# Altium schematic sheet camera_k60.SchDoc
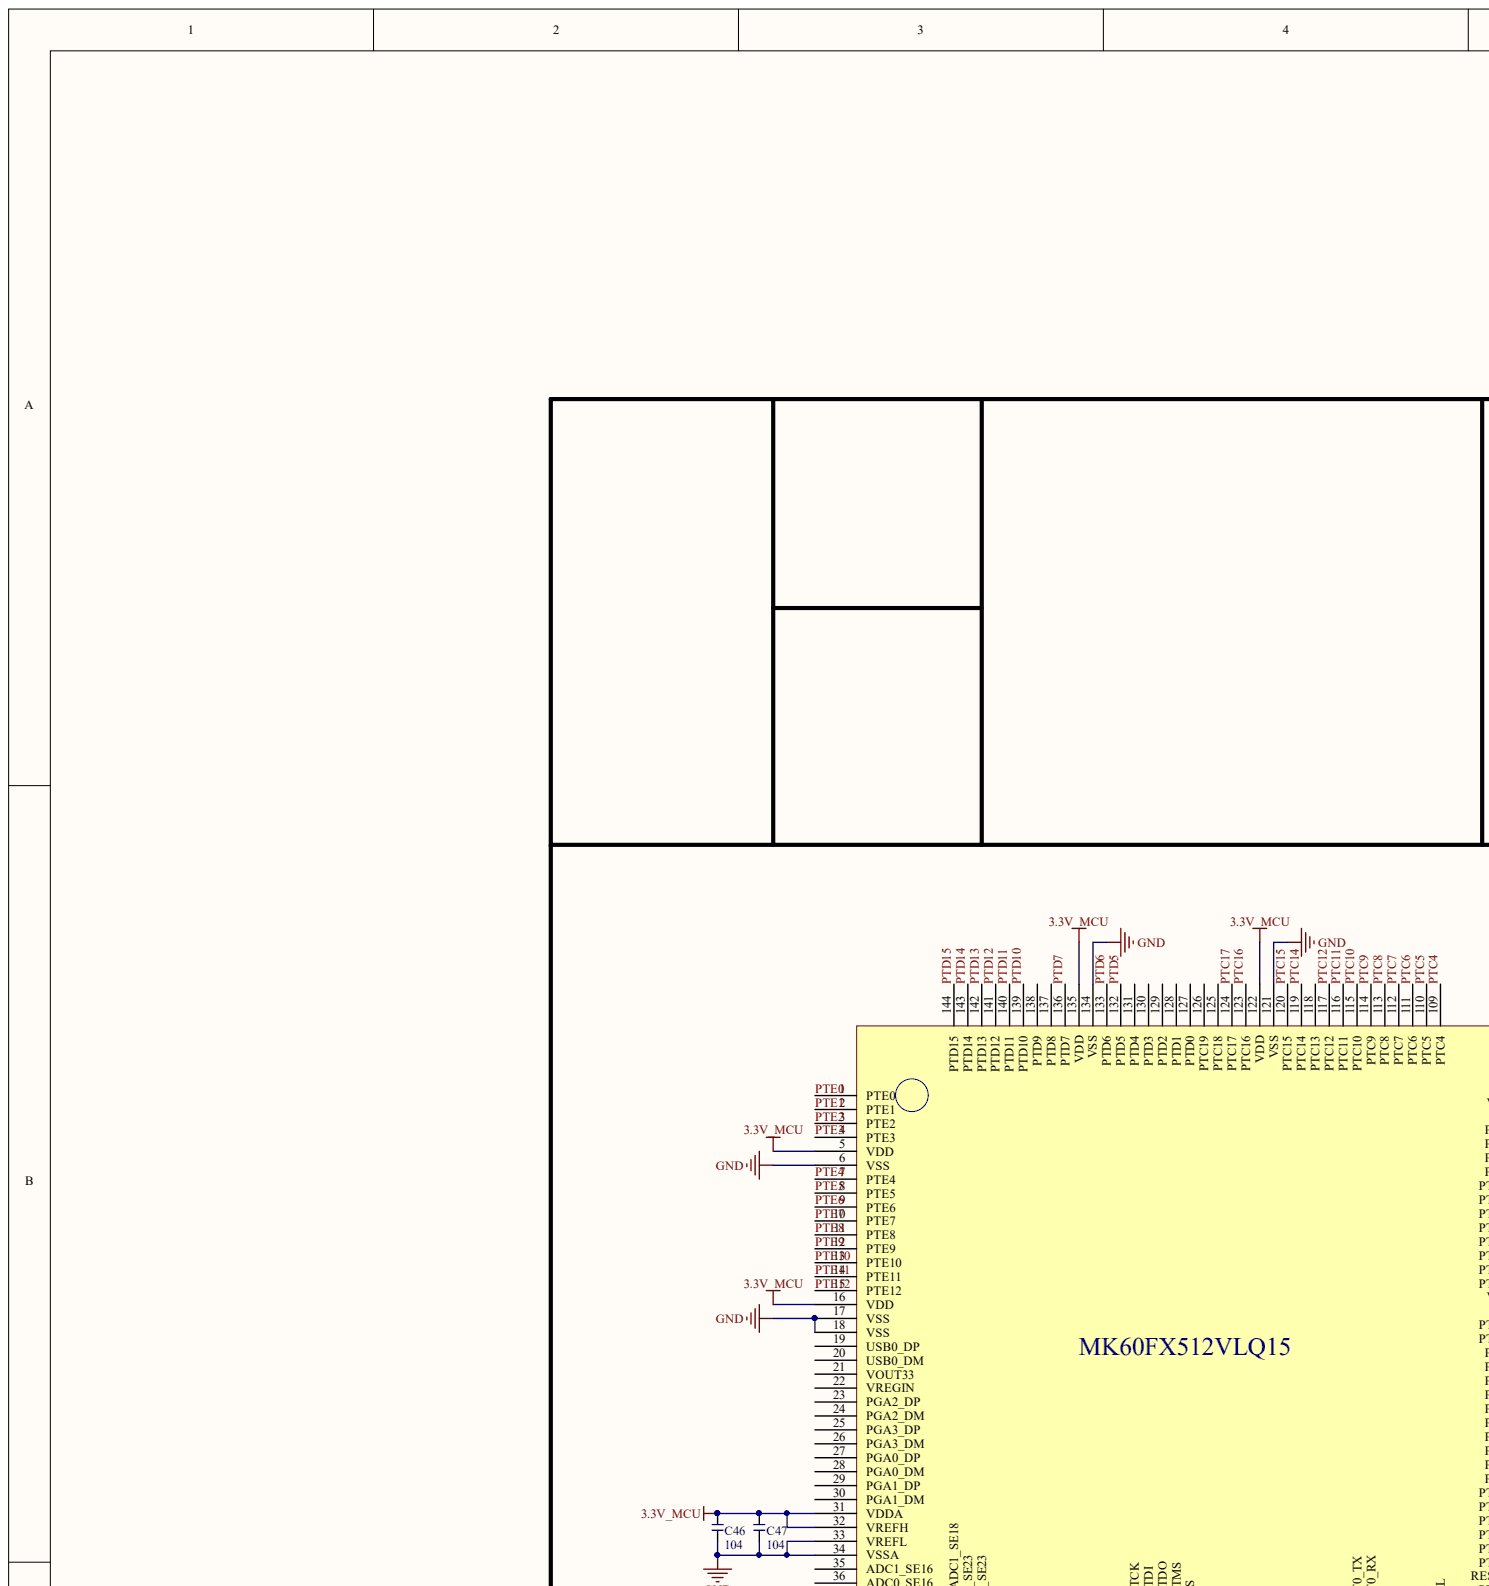
[diagram p0: camera_k60.SchDoc, top left]
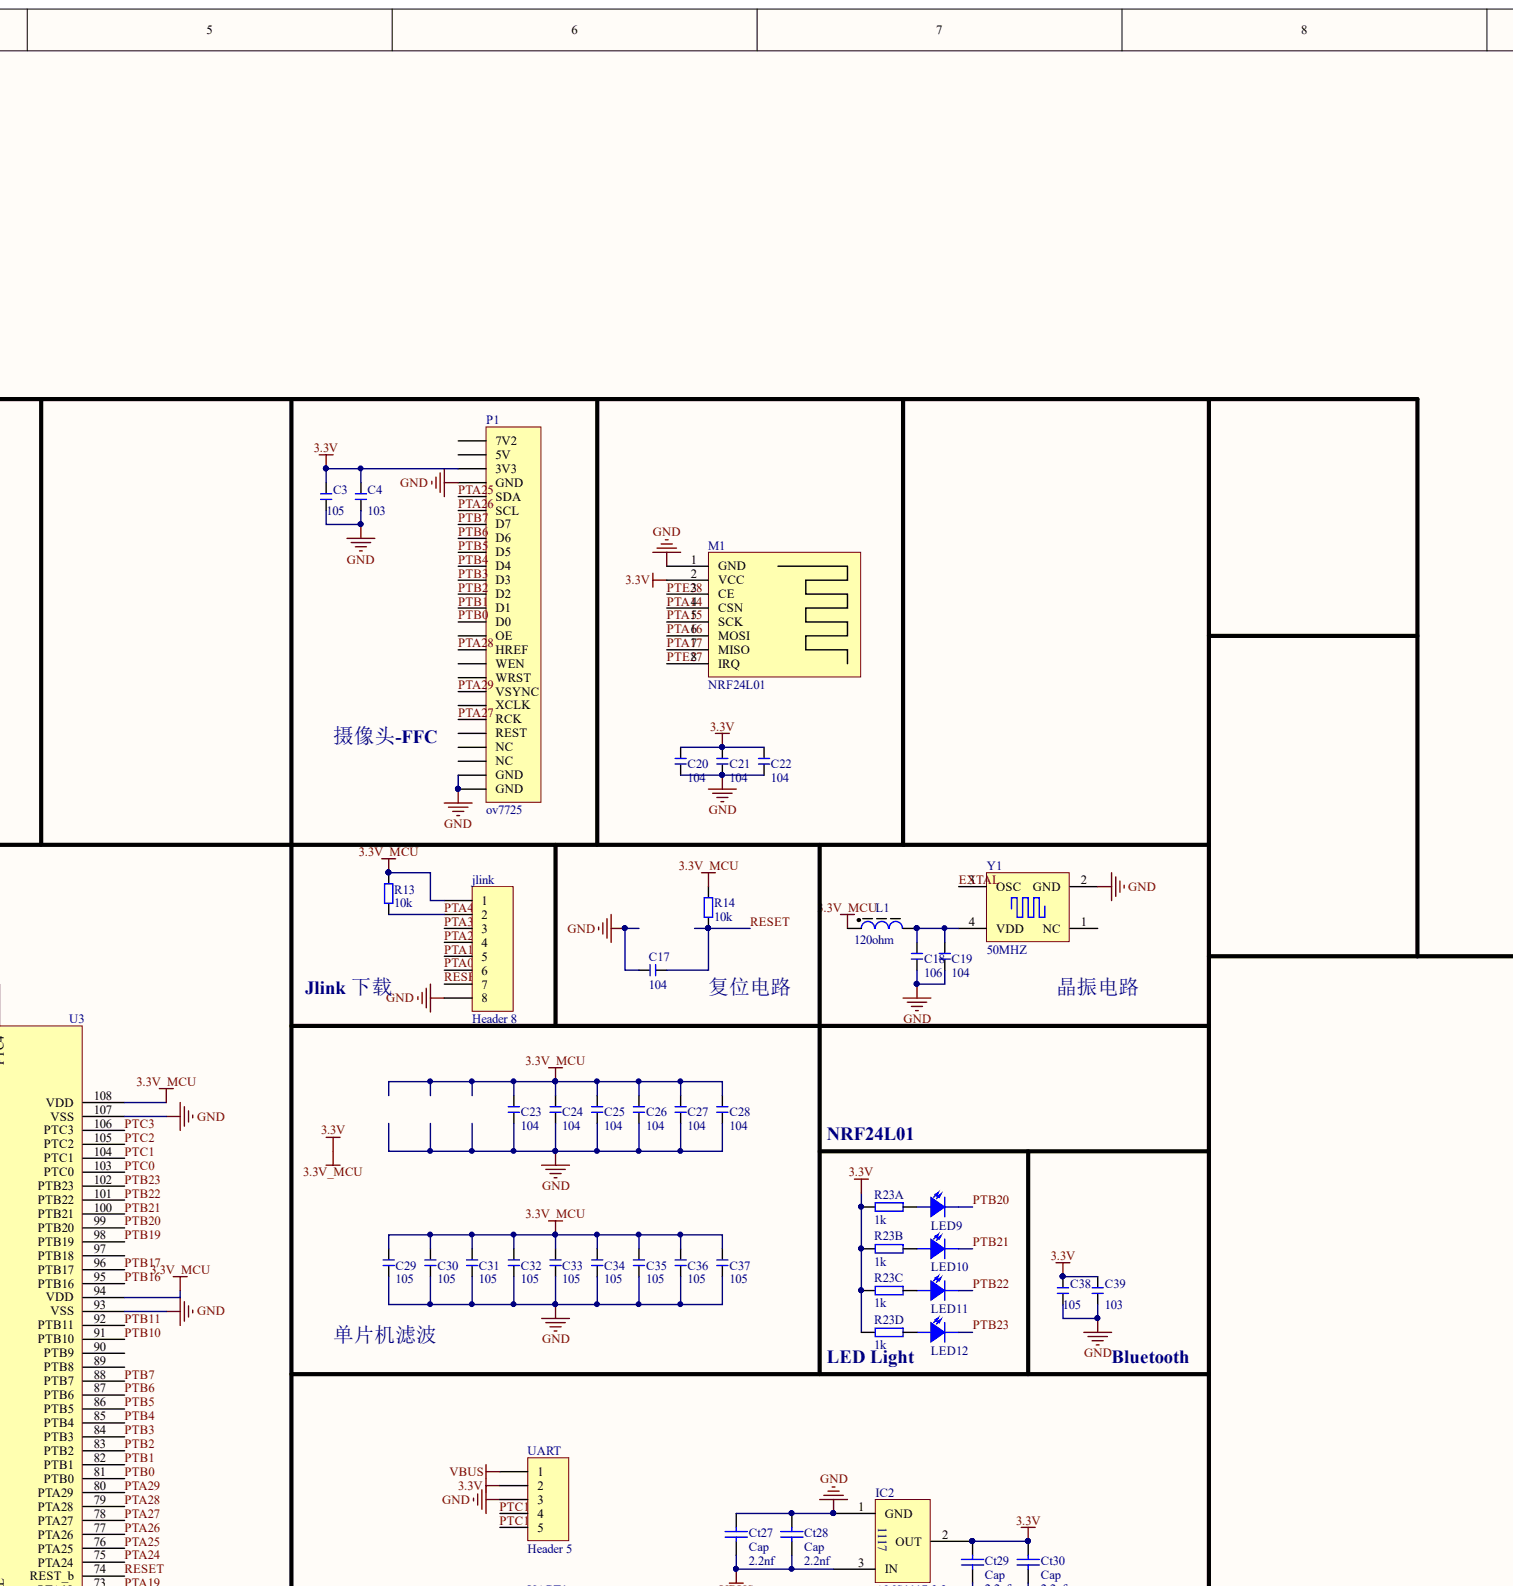
[diagram p1: camera_k60.SchDoc, top centre]
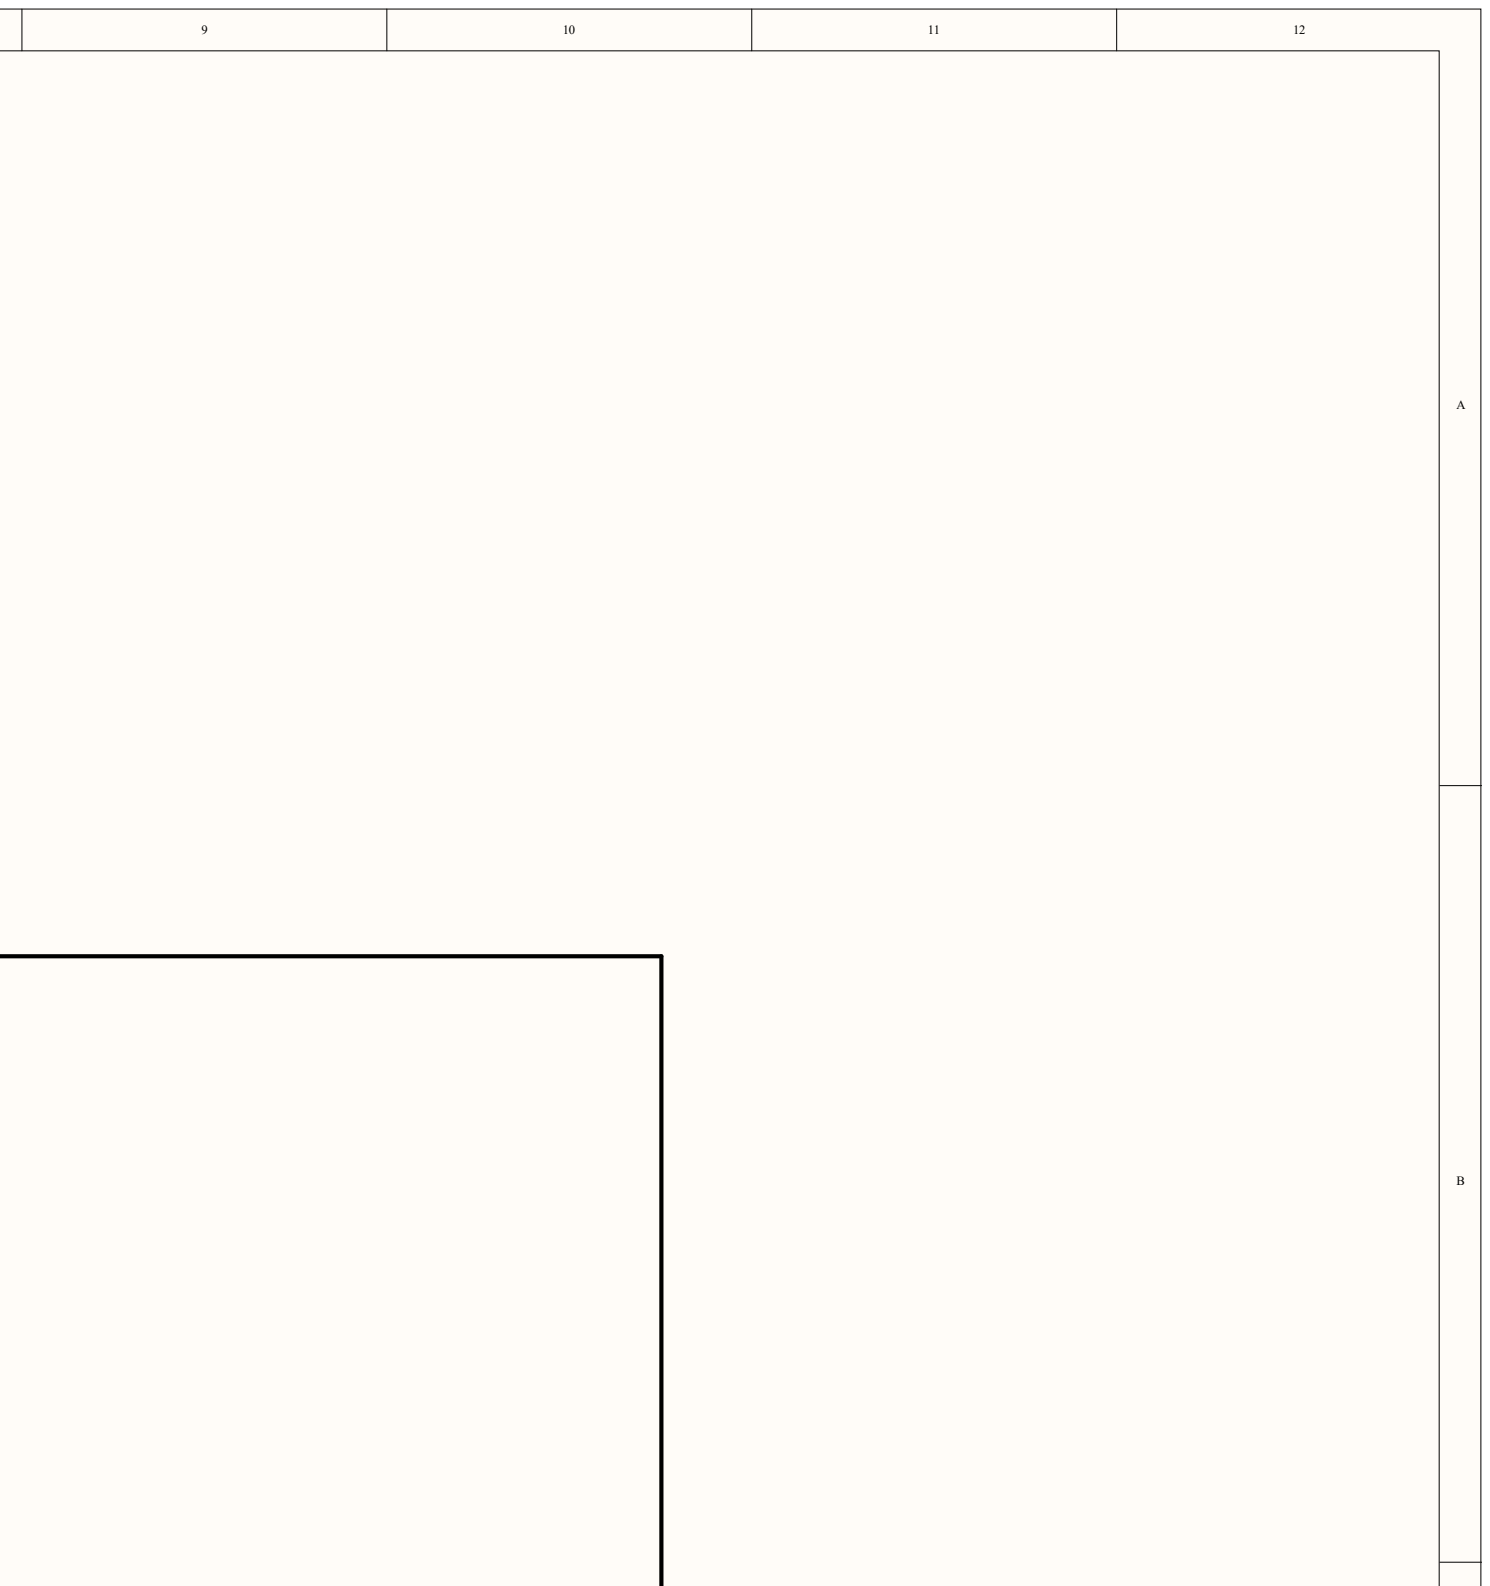
[diagram p2: camera_k60.SchDoc, top right]
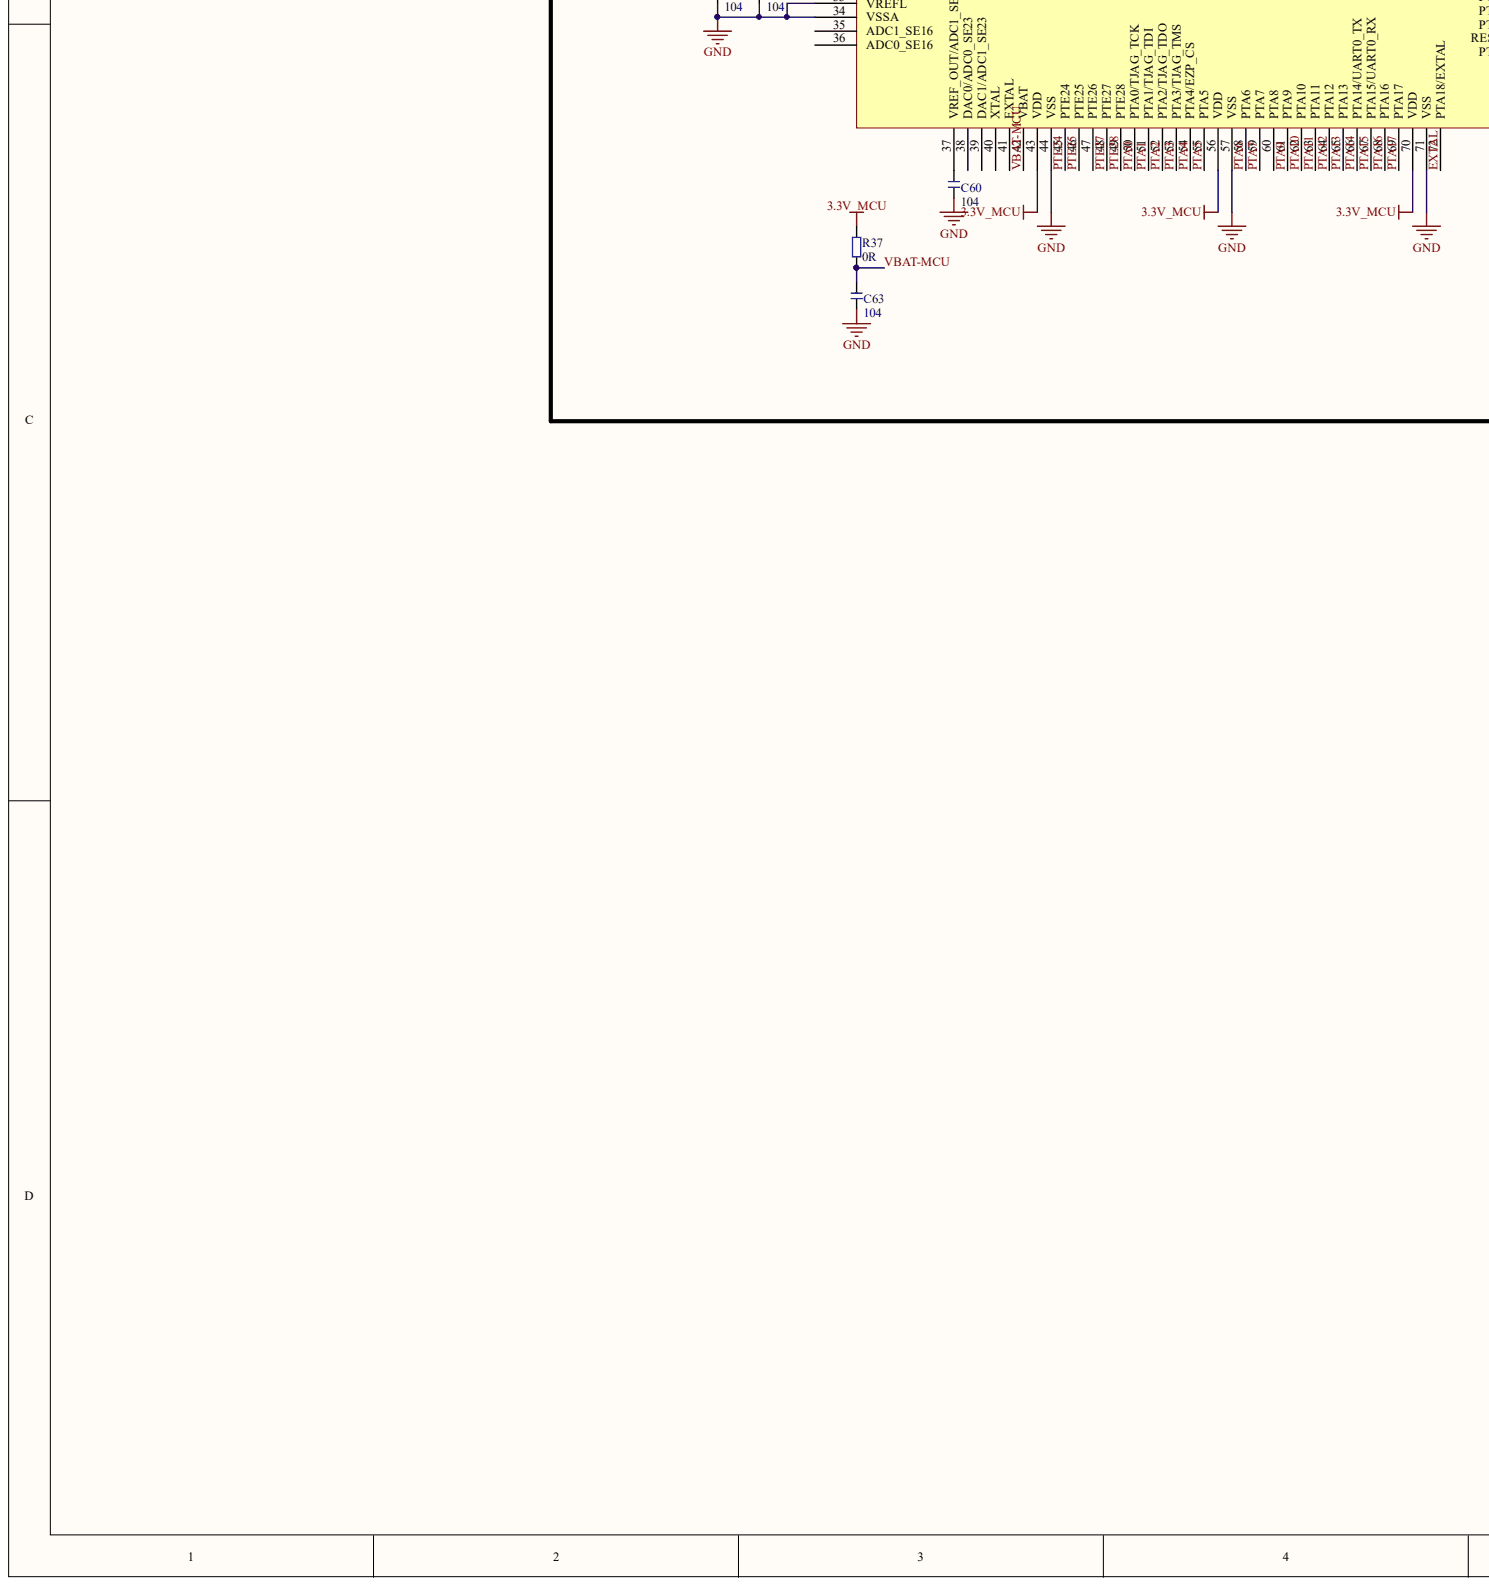
[diagram p3: camera_k60.SchDoc, bottom left]
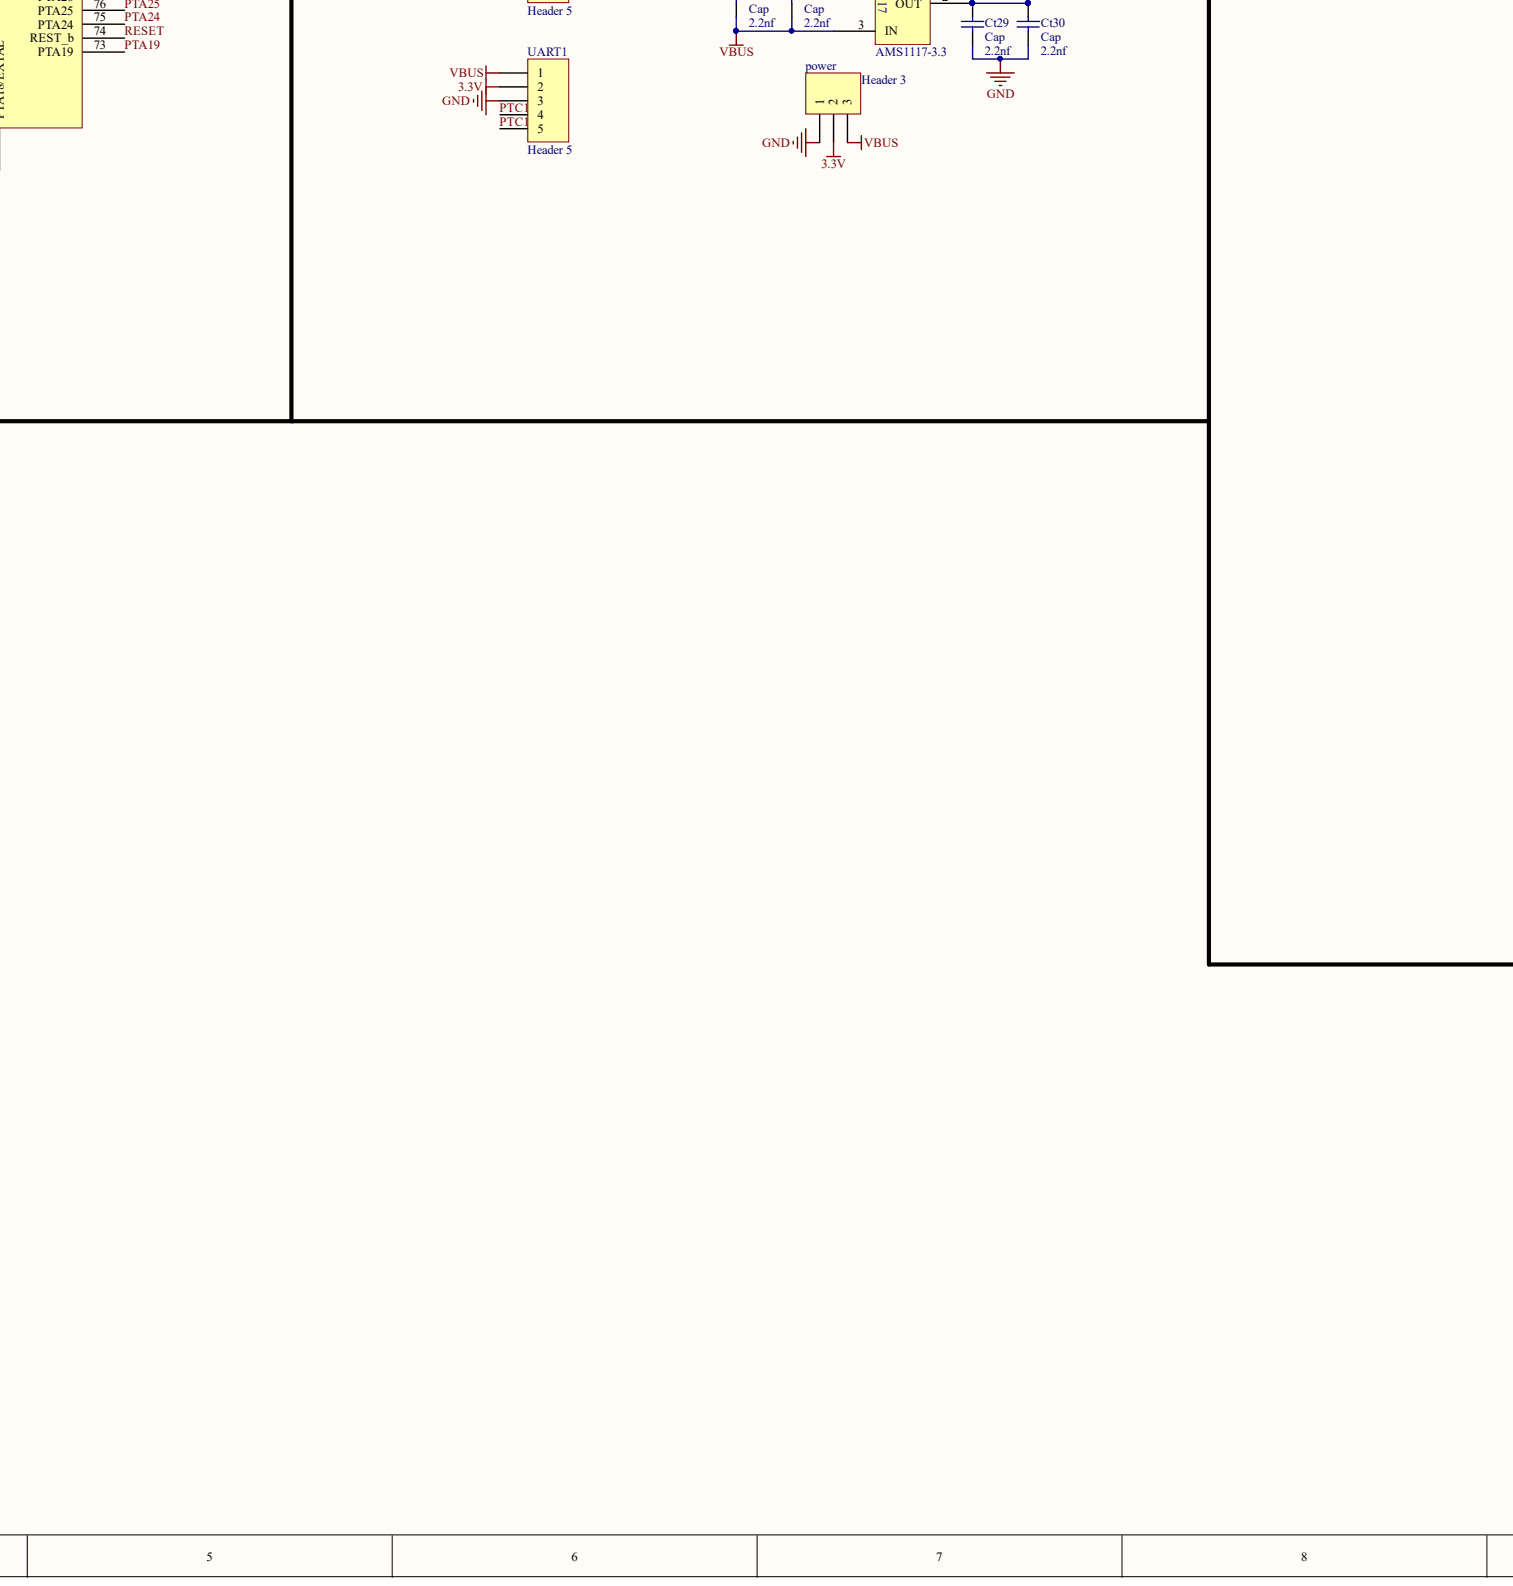
[diagram p4: camera_k60.SchDoc, bottom centre]
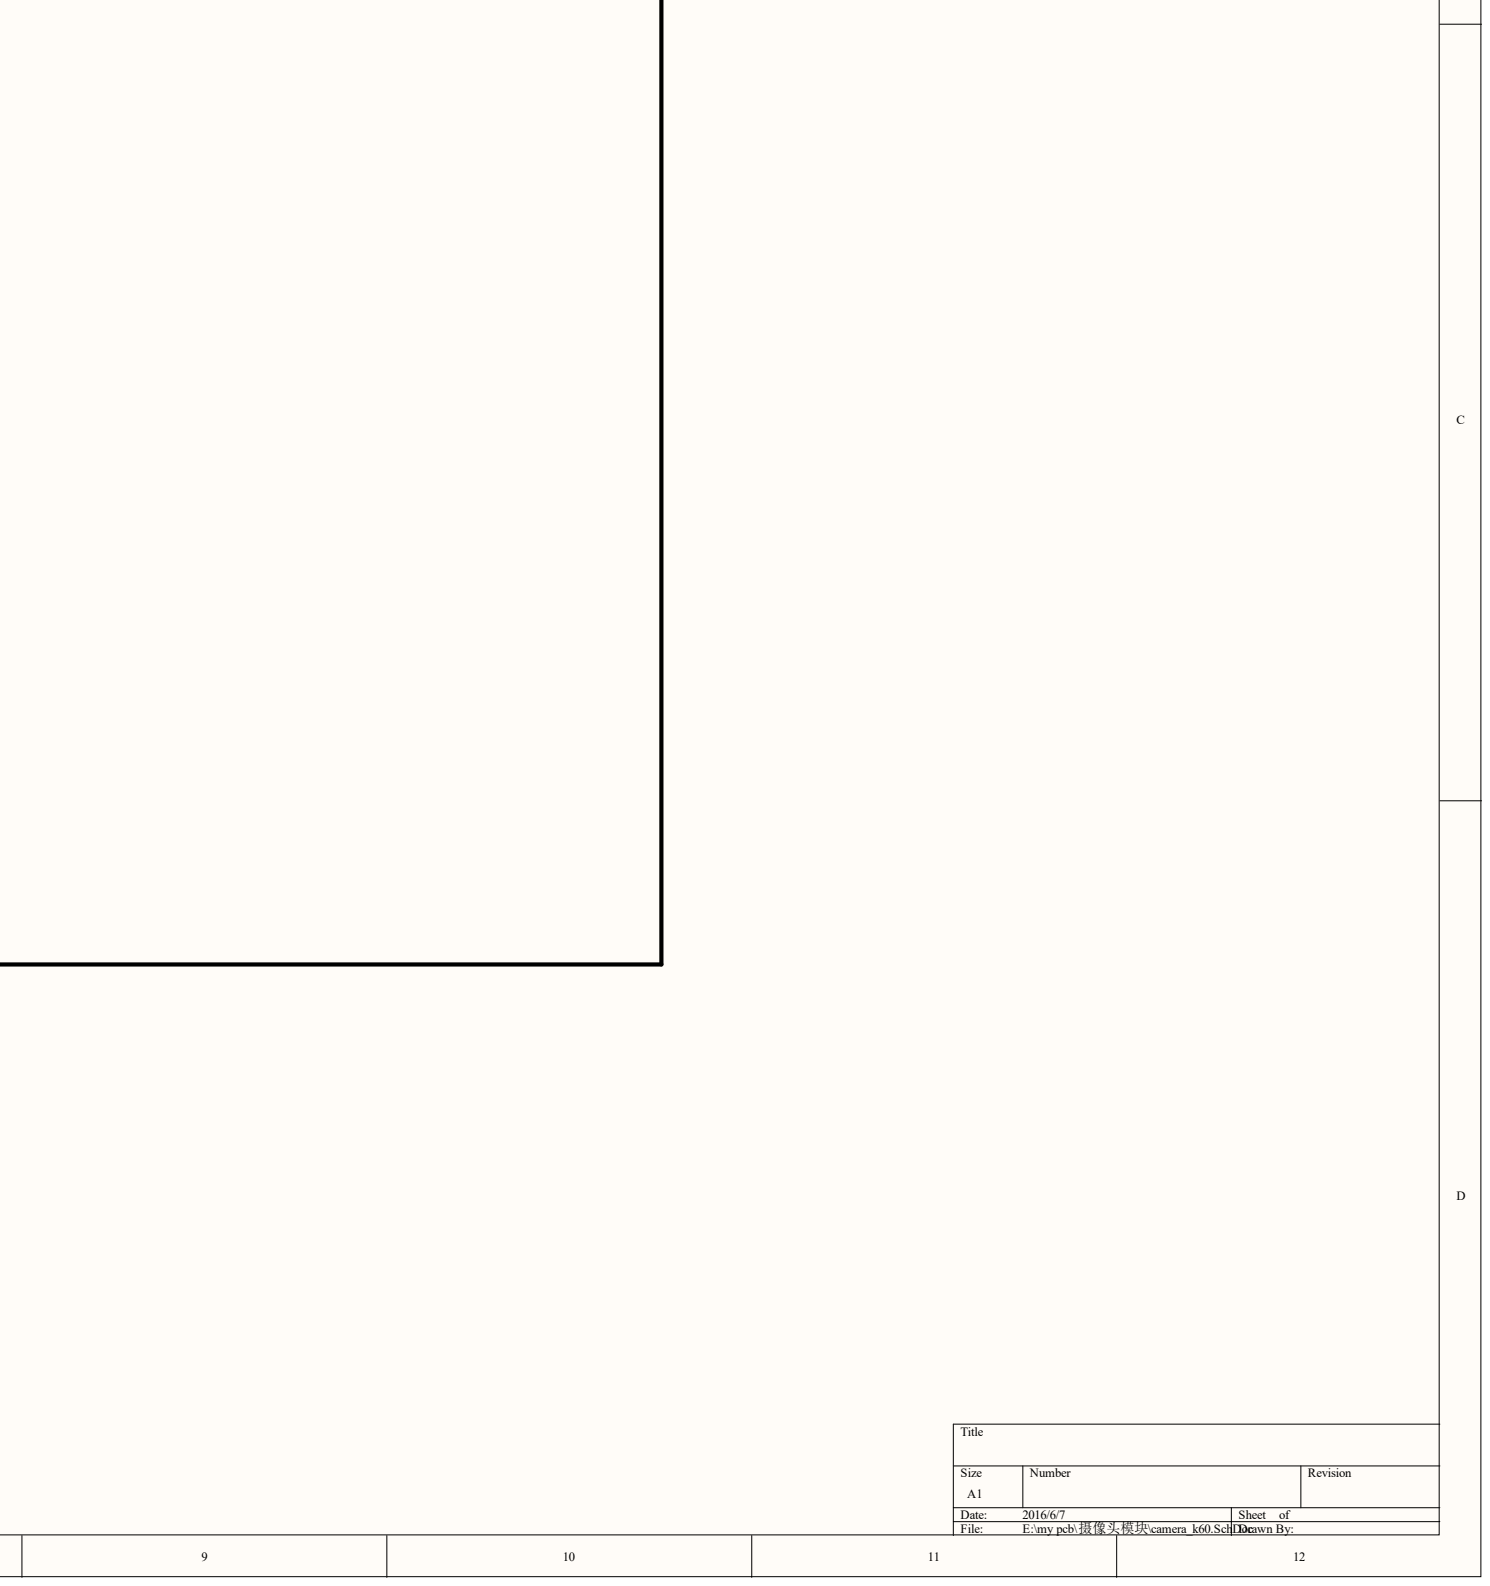
[diagram p5: camera_k60.SchDoc, bottom right]

## Parts
C3  105
C4  103
C17  104
C18  106
C19  104
C20  104
C21  104
C22  104
C23  104
C24  104
C25  104
C26  104
C27  104
C28  104
C29  105
C30  105
C31  105
C32  105
C33  105
C34  105
C35  105
C36  105
C37  105
C38  105
C39  103
C46  104
C47  104
C60  104
C63  104
Ct27  Cap  value 2.2nf
Ct28  Cap  value 2.2nf
Ct29  Cap  value 2.2nf
Ct30  Cap  value 2.2nf
IC2  AMS1117-3.3
jlink  Header 8
L1  L-1  value 120ohm
LED9  LED-B  (value not drawn)
LED10  LED-B  (value not drawn)
LED11  LED-B  (value not drawn)
LED12  LED-B  (value not drawn)
M1  NRF24L01
P1  ov7725
power  Header 3
R13  10k
R14  10k
R23  1k  (parts A,B,C,D)
R37  0R
U3  MK60FX512VLQ15
UART  Header 5
UART1  Header 5
Y1  50MHZ

## Nets
3.3V: C3.2 C4.1 C20.2 C21.2 C22.2 C23.2 C24.2 C25.2 C26.2 C27.2 C28.2 C29.2 C30.2 C31.2 C32.2 C33.2 C34.2 C35.2 C36.2 C37.2 C38.2 C39.1 C46.2 C47.2 Ct29.2 Ct30.2 IC2.2 jlink.1 L1.1 M1.2(VCC) P1.3(3V3) power.2 R13.2 R14.2 R23.1 R23.2 R23.3 R23.4 R37.2 U3.5(VDD) U3.16(VDD) U3.31(VDDA) U3.32(VREFH) U3.43(VDD) U3.56(VDD) U3.70(VDD) U3.94(VDD) U3.108(VDD) U3.122(VDD) U3.135(VDD) UART.2 UART1.2
GND: C3.1 C4.2 C17.2 C18.1 C19.1 C20.1 C21.1 C22.1 C23.1 C24.1 C25.1 C26.1 C27.1 C28.1 C29.1 C30.1 C31.1 C32.1 C33.1 C34.1 C35.1 C36.1 C37.1 C38.1 C39.2 C46.1 C47.1 C60.1 C63.1 Ct27.2 Ct28.2 Ct29.1 Ct30.1 IC2.1 jlink.8 M1.1(GND) P1.4(GND) P1.25(GND) P1.26(GND) power.1 U3.6(VSS) U3.17(VSS) U3.18(VSS) U3.33(VREFL) U3.34(VSSA) U3.44(VSS) U3.57(VSS) U3.71(VSS) U3.93(VSS) U3.107(VSS) U3.121(VSS) U3.134(VSS) UART.3 UART1.3 Y1.2(GND)
VBUS: Ct27.1 Ct28.1 IC2.3 power.3 UART.1 UART1.1
EXTAL: U3.72(PTA18/EXTAL) Y1.3(OSC)
PTA0: jlink.6 U3.50(PTA0/TJAG_TCK)
PTA1: jlink.5 U3.51(PTA1/TJAG_TDI)
PTA2: jlink.4 U3.52(PTA2/TJAG_TDO)
PTA3: jlink.3 U3.53(PTA3/TJAG_TMS)
PTA4: jlink.2 R13.1 U3.54(PTA4/EZP_CS)
PTA5: U3.55(PTA5)
PTA6: U3.58(PTA6)
PTA7: U3.59(PTA7)
PTA9: U3.61(PTA9)
PTA10: U3.62(PTA10)
PTA11: U3.63(PTA11)
PTA12: U3.64(PTA12)
PTA13: U3.65(PTA13)
PTA14: M1.4(CSN) U3.66(PTA14/UART0_TX)
PTA15: M1.5(SCK) U3.67(PTA15/UART0_RX)
PTA16: M1.6(MOSI) U3.68(PTA16)
PTA17: M1.7(MISO) U3.69(PTA17)
PTA19: U3.73(PTA19)
PTA24: U3.75(PTA24)
PTA25: P1.5(SDA) U3.76(PTA25)
PTA26: P1.6(SCL) U3.77(PTA26)
PTA27: P1.21(RCK) U3.78(PTA27)
PTA28: P1.16(HREF) U3.79(PTA28)
PTA29: P1.19(VSYNC) U3.80(PTA29)
PTB0: P1.14(D0) U3.81(PTB0)
PTB1: P1.13(D1) U3.82(PTB1)
PTB2: P1.12(D2) U3.83(PTB2)
PTB3: P1.11(D3) U3.84(PTB3)
PTB4: P1.10(D4) U3.85(PTB4)
PTB5: P1.9(D5) U3.86(PTB5)
PTB6: P1.8(D6) U3.87(PTB6)
PTB7: P1.7(D7) U3.88(PTB7)
PTB10: U3.91(PTB10)
PTB11: U3.92(PTB11)
PTB16: U3.95(PTB16)
PTB17: U3.96(PTB17)
PTB19: U3.98(PTB19)
PTB20: LED9.2 U3.99(PTB20)
PTB21: LED10.2 U3.100(PTB21)
PTB22: LED11.2 U3.101(PTB22)
PTB23: LED12.2 U3.102(PTB23)
PTC0: U3.103(PTC0)
PTC1: U3.104(PTC1)
PTC2: U3.105(PTC2)
PTC3: U3.106(PTC3)
PTC4: U3.109(PTC4)
PTC5: U3.110(PTC5)
PTC6: U3.111(PTC6)
PTC7: U3.112(PTC7)
PTC8: U3.113(PTC8)
PTC9: U3.114(PTC9)
PTC10: U3.115(PTC10)
PTC11: U3.116(PTC11)
PTC12: U3.117(PTC12)
PTC14: U3.119(PTC14) UART.5
PTC15: U3.120(PTC15) UART.4
PTC16: U3.123(PTC16) UART1.5
PTC17: U3.124(PTC17) UART1.4
PTD5: U3.132(PTD5)
PTD6: U3.133(PTD6)
PTD7: U3.136(PTD7)
PTD10: U3.139(PTD10)
PTD11: U3.140(PTD11)
PTD12: U3.141(PTD12)
PTD13: U3.142(PTD13)
PTD14: U3.143(PTD14)
PTD15: U3.144(PTD15)
PTE0: U3.1(PTE0)
PTE1: U3.2(PTE1)
PTE2: U3.3(PTE2)
PTE3: U3.4(PTE3)
PTE4: U3.7(PTE4)
PTE5: U3.8(PTE5)
PTE6: U3.9(PTE6)
PTE7: U3.10(PTE7)
PTE8: U3.11(PTE8)
PTE9: U3.12(PTE9)
PTE10: U3.13(PTE10)
PTE11: U3.14(PTE11)
PTE12: U3.15(PTE12)
PTE24: U3.45(PTE24)
PTE25: U3.46(PTE25)
PTE27: M1.8(IRQ) U3.48(PTE27)
PTE28: M1.3(CE) U3.49(PTE28)
RESET: C17.1 jlink.7 R14.1 U3.74(REST_b)
VBAT-MCU: C63.2 R37.1 U3.42(VBAT)
NetC18_2: C18.2 C19.2 L1.2 Y1.4(VDD)
NetC60_2: C60.2 U3.37(VREF_OUT/ADC1_SE18)
NetLED9_1: LED9.1 R23.8
NetLED10_1: LED10.1 R23.7
NetLED11_1: LED11.1 R23.6
NetLED12_1: LED12.1 R23.5

Not connected: P1 1(7V2) 2(5V) 15(OE) 17(WEN) 18(WRST) 20(XCLK) 22(REST) 23(NC) 24(NC); U3 19(USB0_DP) 20(USB0_DM) 21(VOUT33) 22(VREGIN) 23(PGA2_DP) 24(PGA2_DM) 25(PGA3_DP) 26(PGA3_DM) 27(PGA0_DP) 28(PGA0_DM) 29(PGA1_DP) 30(PGA1_DM) 35(ADC1_SE16) 36(ADC0_SE16) 38(DAC0/ADC0_SE23) 39(DAC1/ADC1_SE23) 40(XTAL) 41(EXTAL) 47(PTE26) 60(PTA8) 89(PTB8) 90(PTB9) 97(PTB18) 118(PTC13) 125(PTC18) 126(PTC19) 127(PTD0) 128(PTD1) 129(PTD2) 130(PTD3) 131(PTD4) 137(PTD8) 138(PTD9); Y1 1(NC)

## Library data of the parts
C3 C4 C17-C39 C46 C47 C60 C63 Ct27-Ct30 IC2 jlink L1 LED9-LED12 M1 P1 power R13 R14 R23 R37 U3 UART UART1 Y1 = (no symbol name)
  C3 C29-C38: Value: 105
  C4 C39: Value: 103
  C17 C19-C28 C46 C47 C60 C63: Value: 104
  C18: Value: 106
  Ct27-Ct30: Published: 8-Jun-2000; LatestRevisionDate: 29-May-2009; LatestRevisionNote: IPC-7351 Footprint Added.; PackageReference: C1206; PackageDescription: Chip Capacitor
  jlink power UART UART1: LatestRevisionDate: 17-Jul-2002; LatestRevisionNote: Re-released for DXP Platform.
  R13 R14: Value: 10k
  R23: Value: 1k
  R37: Value: 0R
  Ct27-Ct30 jlink power UART UART1: Publisher: Altium Limited
